# Altium schematic sheet [1] - POWER.SchDoc("[1] - POWER")
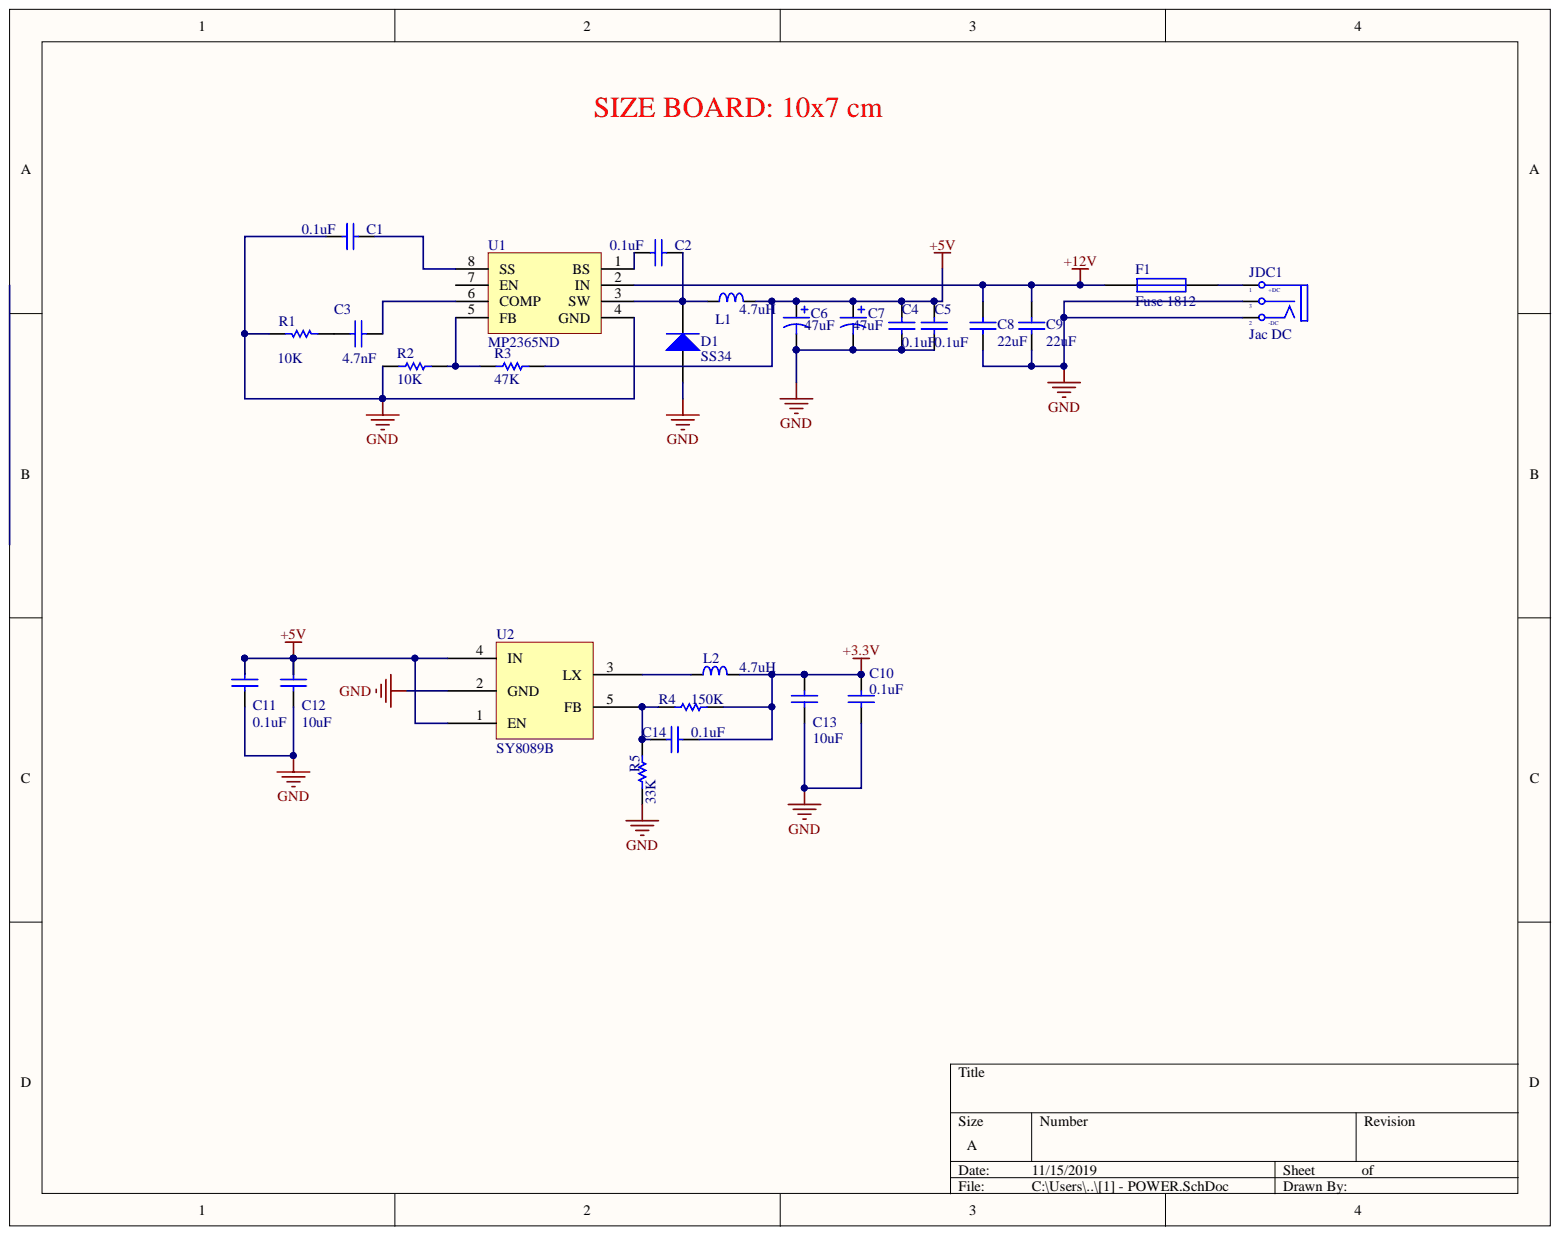
[diagram p0: [1] - POWER.SchDoc]

## Parts
C1  0.1uF  [CN-SMD-0603]
C2  0.1uF  [CN-SMD-0603]
C3  4.7nF  [CN-SMD-0603]
C4  0.1uF  [CN-SMD-0603]
C5  0.1uF  [CN-SMD-0603]
C6  47uF  [Cap size C]
C7  47uF  [Cap size C]
C8  22uF  [CN-SMD-0805]
C9  22uF  [CN-SMD-0805]
C10  0.1uF  [CN-SMD-0603]
C11  0.1uF  [CN-SMD-0603]
C12  10uF  [CN-SMD-0805]
C13  10uF  [CN-SMD-0805]
C14  0.1uF  [CN-SMD-0603]
D1  SS34  [DO-214AC]
F1  Fuse 1812  [fuse_1812]
JDC1  Jac DC  [DC0]
L1  4.7uH  [INDUCTOR CD75]
L2  4.7uH  [INDUCTOR CD75]
R1  10K  [RES 0603]
R2  10K  [RES 0603]
R3  47K  [RES 0603]
R4  150K  [RES 0603]
R5  33K  [RES 0603]
U1  MP2365ND  [MP2365ND]
U2  SY8089B  [SOT23-5]

## Nets
+3.3V: C10.1 C13.2 C14.1 L2.2 R4.1
+5V: C4.2 C5.2 C6.1 C7.1 C11.2 C12.2 L1.1 R3.2 U2.1(EN) U2.4(IN)
+12V: C8.1 C9.2 F1.1 U1.2(IN)
GND: C1.1 C4.1 C5.1 C6.2 C7.2 C8.2 C9.1 C10.2 C11.1 C12.1 C13.1 D1.1 JDC1.2 JDC1.3 R1.2 R2.1 R5.1 U1.4(GND) U2.2(GND)
NetC1_2: C1.2 U1.8(SS)
NetC2_1: C2.1 U1.1(BS)
NetC2_2: C2.2 D1.2 L1.2 U1.3(SW)
NetC3_1: C3.1 R1.1
NetC3_2: C3.2 U1.6(COMP)
NetC14_2: C14.2 R4.2 R5.2 U2.5(FB)
NetF1_2: F1.2 JDC1.1
NetL2_1: L2.1 U2.3(LX)
NetR2_2: R2.2 R3.1 U1.5(FB)
N00005:

Not connected: U1 7(EN)

## Library data of the parts
C1 C2 C4 C5 C10 C11 C14 = CN0603-0.1uF: CC0603KPX7R9BB104 0603 0.1 uF 50 V ±10% Tolerance X7R SMT Multilayer Ceramic Capacitor; Manufacturer 1: Yageo; Capacitance: 100nF; Voltage Rating: 50V; Case/Package: 0603; Case Code (Imperial): 0603; Case Code (Metric): 1608; Component Kind: Standard; ComponentLink1Description: https://datasheet.ciiva.com/26829/getdatasheetpartid-653026-26829414.pdf?src-supplier=Verical; ComponentLink1URL: https://datasheet.ciiva.com/26829/getdatasheetpartid-653026-26829414.pdf?src-supplier=Verical; ComponentLink2Description: http://datasheet.octopart.com/CC0603KPX7R9BB104-Yageo-datasheet-10883670.pdf; ComponentLink2URL: http://datasheet.octopart.com/CC0603KPX7R9BB104-Yageo-datasheet-10883670.pdf; ComponentLink3Description: http://datasheet.octopart.com/CC0603KPX7R9BB104-Yageo-datasheet-68298032.pdf?src-supplier=Future+Electronics; ComponentLink3URL: http://datasheet.octopart.com/CC0603KPX7R9BB104-Yageo-datasheet-68298032.pdf?src-supplier=Future+Electronics; ComponentLink4Description: http://datasheet.octopart.com/CC0603KPX7R9BB104-Yageo-datasheet-78855685.pdf?src-supplier=Future+Electronics; ComponentLink4URL: http://datasheet.octopart.com/CC0603KPX7R9BB104-Yageo-datasheet-78855685.pdf?src-supplier=Future+Electronics; ComponentLink5Description: http://datasheet.octopart.com/CC0603KPX7R9BB104-Yageo-datasheet-82288757.pdf?src-supplier=Future+Electronics; ComponentLink5URL: http://datasheet.octopart.com/CC0603KPX7R9BB104-Yageo-datasheet-82288757.pdf?src-supplier=Future+Electronics; ComponentLink6Description: http://datasheet.octopart.com/CC0603KPX7R9BB104-Yageo-datasheet-137700084.pdf?src-supplier=Future+Electronics; ComponentLink6URL: http://datasheet.octopart.com/CC0603KPX7R9BB104-Yageo-datasheet-137700084.pdf?src-supplier=Future+Electronics; Composition: Ceramic; Depth: 0.8mm; Dielectric: X7R; Halogen Free: Halogen Free; Height: 0.8mm; Lead Free: Lead Free; Length: 1.6mm; Manufacturer Part Number 1: CC0603KPX7R9BB104; Max Operating Temperature: 125°C; Min Operating Temperature: -55°C; Mount: Surface Mount; Number of Pins: 2; Packaging: Tape and Reel; Pricing 1: 1=0.10000, 10=0.06200, 100=0.02780, 500=0.01988, 1000=0.01562, 2500=0.01420, 5000=0.01306 (USD); Radiation Hardening: No; Stock 1: 190619; Supplier 1: Digi-Key; Termination: SMD/SMT; Thickness: 0.035inch; Tolerance: 10%; Voltage Rating (DC): 50V; Weight: 7.1E-05oz; Width: 0.031inch; Library Name: IOT_HIEP.SchLib
C3 = CN0603-4.7nF: CC0603KRX7R9BB472 Surface Mount Ceramic Multilayer Capacitor 0.0047uF 10% X7R 50V 0603 Paper T/R; Manufacturer 1: Yageo; Dissipation Factor: 2.5%; Insulation Resistance: 10GR; Capacitance: 4.7nF; Case/Package: 0603; Case Code (Imperial): 0603; Case Code (Metric): 1608; Component Kind: Standard; ComponentLink1Description: https://datasheet.ciiva.com/144/mlccorderingcode-144445.pdf?src-supplier=Digi-Key; ComponentLink1URL: https://datasheet.ciiva.com/144/mlccorderingcode-144445.pdf?src-supplier=Digi-Key; ComponentLink2Description: https://datasheet.ciiva.com/144/mlccorderingcode-144446.pdf?src-supplier=Digi-Key; ComponentLink2URL: https://datasheet.ciiva.com/144/mlccorderingcode-144446.pdf?src-supplier=Digi-Key; ComponentLink3Description: https://datasheet.ciiva.com/144/mlccorderingcode-144447.pdf?src-supplier=Digi-Key; ComponentLink3URL: https://datasheet.ciiva.com/144/mlccorderingcode-144447.pdf?src-supplier=Digi-Key; ComponentLink4Description: https://datasheet.ciiva.com/11464/upy-gphc-x7r-6-3v-to-50v-8-11464743.pdf?src-supplier=Avnet; ComponentLink4URL: https://datasheet.ciiva.com/11464/upy-gphc-x7r-6-3v-to-50v-8-11464743.pdf?src-supplier=Avnet; ComponentLink5Description: https://datasheet.ciiva.com/16625/upy-gphc-x7r-6-3v-to-50v-8-16625534.pdf?src-supplier=Avnet+Europe; ComponentLink5URL: https://datasheet.ciiva.com/16625/upy-gphc-x7r-6-3v-to-50v-8-16625534.pdf?src-supplier=Avnet+Europe; ComponentLink6Description: https://datasheet.ciiva.com/8033/1489800-8033903.pdf?src-supplier=Element14; ComponentLink6URL: https://datasheet.ciiva.com/8033/1489800-8033903.pdf?src-supplier=Element14; ComponentLink7Description: http://datasheet.octopart.com/CC0603KRX7R9BB472-Yageo-datasheet-10883670.pdf; ComponentLink7URL: http://datasheet.octopart.com/CC0603KRX7R9BB472-Yageo-datasheet-10883670.pdf; ComponentLink8Description: http://datasheet.octopart.com/CC0603KRX7R9BB472-Yageo-datasheet-62336348.pdf?src-supplier=Newark; ComponentLink8URL: http://datasheet.octopart.com/CC0603KRX7R9BB472-Yageo-datasheet-62336348.pdf?src-supplier=Newark; ComponentLink9Description: http://datasheet.octopart.com/CC0603KRX7R9BB472-Yageo-datasheet-5301394.pdf?src-supplier=Newark; ComponentLink9URL: http://datasheet.octopart.com/CC0603KRX7R9BB472-Yageo-datasheet-5301394.pdf?src-supplier=Newark; ComponentLink10Description: http://datasheet.octopart.com/CC0603KRX7R9BB472-Yageo-datasheet-15895757.pdf?src-supplier=Future+Electronics; ComponentLink10URL: http://datasheet.octopart.com/CC0603KRX7R9BB472-Yageo-datasheet-15895757.pdf?src-supplier=Future+Electronics; ComponentLink11Description: http://datasheet.octopart.com/CC0603KRX7R9BB472-Yageo-datasheet-27626582.pdf?src-supplier=Future+Electronics; ComponentLink11URL: http://datasheet.octopart.com/CC0603KRX7R9BB472-Yageo-datasheet-27626582.pdf?src-supplier=Future+Electronics; ComponentLink12Description: http://datasheet.octopart.com/CC0603KRX7R9BB472-Yageo-datasheet-30457685.pdf?src-supplier=Seeed+Studio; ComponentLink12URL: http://datasheet.octopart.com/CC0603KRX7R9BB472-Yageo-datasheet-30457685.pdf?src-supplier=Seeed+Studio; ComponentLink13Description: http://datasheet.octopart.com/CC0603KRX7R9BB472-Yageo-datasheet-17600895.pdf?src-supplier=TME; ComponentLink13URL: http://datasheet.octopart.com/CC0603KRX7R9BB472-Yageo-datasheet-17600895.pdf?src-supplier=TME; ComponentLink14Description: http://datasheet.octopart.com/CC0603KRX7R9BB472-Yageo-datasheet-137700084.pdf?src-supplier=Future+Electronics; ComponentLink14URL: http://datasheet.octopart.com/CC0603KRX7R9BB472-Yageo-datasheet-137700084.pdf?src-supplier=Future+Electronics; ComponentLink15Description: http://datasheet.octopart.com/CC0603KRX7R9BB472-Yageo-datasheet-118396.pdf?src-supplier=Digi-Key; ComponentLink15URL: http://datasheet.octopart.com/CC0603KRX7R9BB472-Yageo-datasheet-118396.pdf?src-supplier=Digi-Key; ComponentLink16Description: http://datasheet.octopart.com/CC0603KRX7R9BB472-Yageo-datasheet-8324192.pdf?src-supplier=Future+Electronics; ComponentLink16URL: http://datasheet.octopart.com/CC0603KRX7R9BB472-Yageo-datasheet-8324192.pdf?src-supplier=Future+Electronics; ComponentLink17Description: http://datasheet.octopart.com/CC0603KRX7R9BB472-Yageo-datasheet-499490.pdf?src-supplier=Element14; ComponentLink17URL: http://datasheet.octopart.com/CC0603KRX7R9BB472-Yageo-datasheet-499490.pdf?src-supplier=Element14; ComponentLink18Description: http://datasheet.octopart.com/CC0603KRX7R9BB472-Yageo-datasheet-8701427.pdf?src-supplier=Element14; ComponentLink18URL: http://datasheet.octopart.com/CC0603KRX7R9BB472-Yageo-datasheet-8701427.pdf?src-supplier=Element14; ComponentLink19Description: http://datasheet.octopart.com/CC0603KRX7R9BB472-Yageo-datasheet-12526321.pdf?src-supplier=Future+Electronics; ComponentLink19URL: http://datasheet.octopart.com/CC0603KRX7R9BB472-Yageo-datasheet-12526321.pdf?src-supplier=Future+Electronics; Composition: Ceramic; Depth: 0.8mm; Dielectric: X7R; Dielectric Material: Ceramic; Halogen Free: Halogen Free; Height: 0.8mm; Lead Free: Lead Free; Length: 1.6mm; Manufacturer Part Number 1: CC0603KRX7R9BB472; Material: Ceramic; Max Operating Temperature: 125°C; Min Operating Temperature: -55°C; Mount: Surface Mount; Number of Pins: 2; Package Quantity: 1; Packaging: Tape and Reel; Pricing 1: 1=0.10000, 10=0.05200, 100=0.02320, 500=0.01656, 1000=0.01301 (USD); Radiation Hardening: No; REACH SVHC: Unknown; Stock 1: 731800; Supplier 1: Digi-Key; Termination: SMD/SMT; Thickness: 0.035inch; Tolerance: 10%; Voltage: 50V; Voltage Rating: 50V; Voltage Rating (DC): 50V; Width: 0.8mm; Library Name: IOT_HIEP.SchLib
C6 C7 = CN6032-47uF: TPSC476M016R0350 CAP TANT 47UF 16V 20% 2312; Manufacturer 1: Kyocera AVX; Dielectric Material: Tantalum; Dissipation Factor: 6%; ESR (Equivalent Series Resistance): 350mR; Polarity: Polar; Capacitance: 47uF; Case/Package: Molded; Case Code (Imperial): 2312; Case Code (Metric): 6032; Component Kind: Standard; ComponentLink1Description: https://datasheet.ciiva.com/264/tps-264405.pdf?src-supplier=Digi-Key; ComponentLink1URL: https://datasheet.ciiva.com/264/tps-264405.pdf?src-supplier=Digi-Key; ComponentLink2Description: https://datasheet.ciiva.com/264/tps-264406.pdf?src-supplier=Digi-Key; ComponentLink2URL: https://datasheet.ciiva.com/264/tps-264406.pdf?src-supplier=Digi-Key; ComponentLink3Description: https://datasheet.ciiva.com/22667/1724878-22667574.pdf?src-supplier=Element14; ComponentLink3URL: https://datasheet.ciiva.com/22667/1724878-22667574.pdf?src-supplier=Element14; ComponentLink4Description: https://datasheet.ciiva.com/264/tps-264407.pdf?src-supplier=Digi-Key; ComponentLink4URL: https://datasheet.ciiva.com/264/tps-264407.pdf?src-supplier=Digi-Key; ComponentLink5Description: https://datasheet.ciiva.com/28514/1724878-28514871.pdf?src-supplier=Element14; ComponentLink5URL: https://datasheet.ciiva.com/28514/1724878-28514871.pdf?src-supplier=Element14; Depth: 3.2mm; Features: General Purpose; Height: 2.8mm; Height - Seated (Max): 0.11inch; Lead Free: Lead Free; Length: 6mm; Manufacturer Part Number 1: TPSC476M016R0350; Max Operating Temperature: 125°C; Min Operating Temperature: -55°C; Mount: Surface Mount; Number of Pins: 2; Package Quantity: 1; Packaging: Tape and Reel; Pricing 1: 1=1.31000, 10=1.03500, 100=0.77630 (USD); Radiation Hardening: No; REACH SVHC: No SVHC; RoHS: Yes; Stock 1: 3387; Supplier 1: Digi-Key; Termination: SMD/SMT; Tolerance: 20%; Voltage: 16V; Voltage Rating: 16V; Voltage Rating (DC): 16V; Width: 3.2mm; Library Name: IOT_HIEP.SchLib
C8 C9 = CN0805-22uF: CC0805MKX5R6BB226 0805 22 uF 10 V ±20 % Tolerance X5R SMT Multilayer Ceramic Capacitor; Manufacturer 1: Yageo; Tolerance: 20%; Voltage Rating: 10V; Capacitance: 22uF; Case/Package: 0805; Case Code (Imperial): 0805; Case Code (Metric): 2012; Component Kind: Standard; ComponentLink1Description: https://datasheet.ciiva.com/26863/getdatasheetpartid-573628-26863004.pdf?src-supplier=Verical; ComponentLink1URL: https://datasheet.ciiva.com/26863/getdatasheetpartid-573628-26863004.pdf?src-supplier=Verical; ComponentLink2Description: http://datasheet.octopart.com/CC0805MKX5R6BB226-Yageo-datasheet-24580494.pdf?src-supplier=Future+Electronics; ComponentLink2URL: http://datasheet.octopart.com/CC0805MKX5R6BB226-Yageo-datasheet-24580494.pdf?src-supplier=Future+Electronics; ComponentLink3Description: http://datasheet.octopart.com/CC0805MKX5R6BB226-Yageo-datasheet-27626583.pdf?src-supplier=Future+Electronics; ComponentLink3URL: http://datasheet.octopart.com/CC0805MKX5R6BB226-Yageo-datasheet-27626583.pdf?src-supplier=Future+Electronics; ComponentLink4Description: http://datasheet.octopart.com/CC0805MKX5R6BB226-Yageo-datasheet-86397281.pdf?src-supplier=Future+Electronics; ComponentLink4URL: http://datasheet.octopart.com/CC0805MKX5R6BB226-Yageo-datasheet-86397281.pdf?src-supplier=Future+Electronics; Composition: Ceramic; Depth: 1.25mm; Dielectric: X5R; Halogen Free: Halogen Free; Lead Free: Lead Free; Length: 2mm; Manufacturer Part Number 1: CC0805MKX5R6BB226; Max Operating Temperature: 85°C; Min Operating Temperature: -55°C; Mount: Surface Mount; Packaging: Tape and Reel; Pricing 1: 1=0.41000, 10=0.28800, 100=0.17290, 500=0.12966, 1000=0.10950 (USD); Radiation Hardening: No; Stock 1: 18000; Supplier 1: Digi-Key; Termination: SMD/SMT; Thickness: 0.057inch; Voltage Rating (DC): 10V; Weight: 0.000194oz; Width: 1.25mm; Library Name: IOT_HIEP.SchLib
C12 C13 = CN0805_10uF: CC0805KKX5R8BB106 0805 10 uF 25 V ±10 % Tolerance X5R SMT Multilayer Ceramic Capacitor; Manufacturer 1: Yageo; Tolerance: 10%; Voltage Rating: 25V; Capacitance: 10uF; Case/Package: 0805; Case Code (Imperial): 0805; Case Code (Metric): 2012; Component Kind: Standard; ComponentLink1Description: http://www.mouser.com/ds/2/447/UPY-GPHC_X5R_4V-to-50V_25-1131599.pdf?src-supplier=Mouser; ComponentLink1URL: http://www.mouser.com/ds/2/447/UPY-GPHC_X5R_4V-to-50V_25-1131599.pdf?src-supplier=Mouser; ComponentLink2Description: http://components.arrow.com/part/dataview/0323_04166572?src-supplier=Arrow+Europe; ComponentLink2URL: http://components.arrow.com/part/dataview/0323_04166572?src-supplier=Arrow+Europe; ComponentLink3Description: http://www.yageo.com/documents/recent/UPY-GPHC_X5R_4V-to-50V_25.pdf?src-supplier=Digi-Key; ComponentLink3URL: http://www.yageo.com/documents/recent/UPY-GPHC_X5R_4V-to-50V_25.pdf?src-supplier=Digi-Key; ComponentLink4Description: http://datasheet.octopart.com/CC0805KKX5R8BB106-Yageo-datasheet-14389981.pdf?src-supplier=Seeed+Studio; ComponentLink4URL: http://datasheet.octopart.com/CC0805KKX5R8BB106-Yageo-datasheet-14389981.pdf?src-supplier=Seeed+Studio; ComponentLink5Description: http://datasheet.octopart.com/CC0805KKX5R8BB106-Yageo-datasheet-78855687.pdf?src-supplier=Future+Electronics; ComponentLink5URL: http://datasheet.octopart.com/CC0805KKX5R8BB106-Yageo-datasheet-78855687.pdf?src-supplier=Future+Electronics; ComponentLink6Description: http://datasheet.octopart.com/CC0805KKX5R8BB106-Yageo-datasheet-86397281.pdf?src-supplier=Future+Electronics; ComponentLink6URL: http://datasheet.octopart.com/CC0805KKX5R8BB106-Yageo-datasheet-86397281.pdf?src-supplier=Future+Electronics; Composition: Ceramic; Depth: 1.25mm; Dielectric: X5R; Halogen Free: Halogen Free; Lead Free: Lead Free; Length: 0.079inch; Manufacturer Part Number 1: CC0805KKX5R8BB106; Max Operating Temperature: 85°C; Min Operating Temperature: -55°C; Mount: Surface Mount; Packaging: Tape and Reel; Pricing 1: 1=0.48000, 10=0.33500, 100=0.20100, 500=0.15076, 1000=0.12730 (USD); Stock 1: 905270; Supplier 1: Digi-Key; Termination: SMD/SMT; Thickness: 0.057inch; Voltage Rating (DC): 25V; Weight: 0.000194oz; Width: 0.049inch; Library Name: IOT_HIEP.SchLib
D1 = Diode_SS34: SS34 DIODE SCHOTTKY 40V 3A SMC; Manufacturer 1: ON Semiconductor / Fairchild; Element Configuration: Single; Forward Voltage: 500mV; Max Forward Surge Current (Ifsm): 100A; Max Power Dissipation: 2.27W; Max Reverse Leakage Current: 500uA; Number of Elements: 1; Polarity: Standard; Average Rectified Current: 3A; Case/Package: SMC; Component Kind: Standard; ComponentLink1Description: https://datasheet.ciiva.com/911/ss34-911088.pdf?src-supplier=Avnet; ComponentLink1URL: https://datasheet.ciiva.com/911/ss34-911088.pdf?src-supplier=Avnet; ComponentLink2Description: https://datasheet.ciiva.com/4715/ss34-197057-4715255.pdf?src-supplier=Mouser; ComponentLink2URL: https://datasheet.ciiva.com/4715/ss34-197057-4715255.pdf?src-supplier=Mouser; ComponentLink3Description: https://datasheet.ciiva.com/34301/1090087-34301975.pdf?src-supplier=Jameco; ComponentLink3URL: https://datasheet.ciiva.com/34301/1090087-34301975.pdf?src-supplier=Jameco; ComponentLink4Description: https://datasheet.ciiva.com/23832/1725604-23832651.pdf?src-supplier=Newark; ComponentLink4URL: https://datasheet.ciiva.com/23832/1725604-23832651.pdf?src-supplier=Newark; ComponentLink5Description: https://datasheet.ciiva.com/34302/1547649-34302462.pdf?src-supplier=Jameco; ComponentLink5URL: https://datasheet.ciiva.com/34302/1547649-34302462.pdf?src-supplier=Jameco; ComponentLink6Description: https://datasheet.ciiva.com/911/ss34-911086.pdf?src-supplier=Digi-Key; ComponentLink6URL: https://datasheet.ciiva.com/911/ss34-911086.pdf?src-supplier=Digi-Key; ComponentLink7Description: https://datasheet.ciiva.com/8300/ss39-8300583.pdf?src-supplier=Avnet; ComponentLink7URL: https://datasheet.ciiva.com/8300/ss39-8300583.pdf?src-supplier=Avnet; ComponentLink8Description: https://datasheet.ciiva.com/911/ss34-911085.pdf?src-supplier=Digi-Key; ComponentLink8URL: https://datasheet.ciiva.com/911/ss34-911085.pdf?src-supplier=Digi-Key; ComponentLink9Description: https://datasheet.ciiva.com/7965/1774678-7965245.pdf?src-supplier=Element14; ComponentLink9URL: https://datasheet.ciiva.com/7965/1774678-7965245.pdf?src-supplier=Element14; ComponentLink10Description: https://datasheet.ciiva.com/911/ss34-911087.pdf?src-supplier=Digi-Key; ComponentLink10URL: https://datasheet.ciiva.com/911/ss34-911087.pdf?src-supplier=Digi-Key; Contact Plating: Tin,Matte; Current: 3A; Current Rating: 3A; Forward Current: 3A; Height: 2.75mm; Lead Free: Lead Free; Length: 7.15mm; Manufacturer Part Number 1: SS34; Max Operating Temperature: 150°C; Max Repetitive Reverse Voltage (Vrrm): 40V; Max Reverse Voltage (DC): 40V; Max Surge Current: 100A; Min Operating Temperature: -55°C; Mount: Surface Mount; Number of Pins: 2; Number of Terminals: 2; Output Current: 3A; Package Quantity: 1; Packaging: Tape and Reel; Peak Non-Repetitive Surge Current: 100A; Peak Reverse Current: 500uA; Pricing 1: 1=0.47000, 10=0.40600, 100=0.30310, 500=0.23816, 1000=0.18403 (USD); Radiation Hardening: No; REACH SVHC: No SVHC; RoHS: Yes; Stock 1: 1630; Supplier 1: Digi-Key; Voltage: 40V; Voltage Rating (DC): 40V; Width: 6.25mm; Library Name: IOT_HIEP.SchLib
F1 = Fuse 1: Fuse; Manufacturer: Littelfuse; Manufacturer Part Number: MINISMDC150F/24-2; Hold Current: 1.5A; Voltage Rating (DC): 24V; Component Kind: Standard; ComponentLink1Description: http://www.farnell.com/datasheets/2282569.pdf?src-supplier=Farnell; ComponentLink1URL: http://www.farnell.com/datasheets/2282569.pdf?src-supplier=Farnell; ComponentLink2Description: http://www.farnell.com/datasheets/1468628.pdf?src-supplier=Farnell; ComponentLink2URL: http://www.farnell.com/datasheets/1468628.pdf?src-supplier=Farnell; ComponentLink3Description: http://www.littelfuse.com/~/media/electronics/datasheets/resettable_ptcs/littelfuse_ptc_minismd_catalog_datasheet.pdf.pdf?src-supplier=Digi-Key; ComponentLink3URL: http://www.littelfuse.com/~/media/electronics/datasheets/resettable_ptcs/littelfuse_ptc_minismd_catalog_datasheet.pdf.pdf?src-supplier=Digi-Key; ComponentLink4Description: http://www.farnell.com/datasheets/1468628.pdf?src-supplier=Element14; ComponentLink4URL: http://www.farnell.com/datasheets/1468628.pdf?src-supplier=Element14; Lead Free: Lead Free; Max Operating Temperature: 85°C; Min Operating Temperature: -40°C; Pricing 1: 1 = 0.66000, 5 = 0.64400, 10 = 0.62400, 50 = 0.52480, 100 = 0.43560, 250 = 0.39600, 500 = 0.33660 (USD); REACH SVHC: Unknown; RoHS: No; Simulation: FUSE; Stock 1: 56020; Supplier 1: Digi-Key; Supplier Part Number 1: MINISMDC150F24-2CT-ND; Library Name: NestHouse.SCHLIB
JDC1 = DCIN_1: Jac DC input; Component Kind: Standard; Value: Jac DC; Library Name: THUVIENTUTAO.lib
L1 L2 = L-4.7uH: CDRH5D28RHPNP-4R7NC Fixed Inductors 4.7uH 3.05A; Manufacturer 1: Sumida; Core Material: Ferrite; Current Rating: 2.8A; DC Resistance (DCR): 43.1mR; Max DC Current: 3.7A; Shielding: Shielded; Test Frequency: 100kHz; Tolerance: 25%; Case/Package: Nonstandard; Component Kind: Standard; ComponentLink1Description: http://www.mouser.com/ds/2/390/CDRH5D28RHP-523509.pdf?src-supplier=Mouser; ComponentLink1URL: http://www.mouser.com/ds/2/390/CDRH5D28RHP-523509.pdf?src-supplier=Mouser; ComponentLink2Description: http://products.sumida.com/products/pdf/CDRH5D28RHP.pdf?src-supplier=Digi-Key; ComponentLink2URL: http://products.sumida.com/products/pdf/CDRH5D28RHP.pdf?src-supplier=Digi-Key; ComponentLink3Description: http://www.sumida.com/products/pdf/CDRH5D28,5D28R,5D28RHP.pdf?src-supplier=Avnet; ComponentLink3URL: http://www.sumida.com/products/pdf/CDRH5D28,5D28R,5D28RHP.pdf?src-supplier=Avnet; Depth: 6.2mm; Height: 3mm; Height - Seated (Max): 0.118inch; Inductance: 4.7uH; Lead Free: Lead Free; Length: 0.236inch; Manufacturer Part Number 1: CDRH5D28RHPNP-4R7NC; Max Operating Temperature: 105°C; Min Operating Temperature: -40°C; Mount: Surface Mount; Packaging: Tape and Reel; Pricing 1: 1=1.53000, 10=1.42000, 100=1.02700, 500=0.78660, 1000=0.69920 (USD); Radiation Hardening: No; RoHS: Yes; Saturation Current: 3.7A; Stock 1: 50646; Supplier 1: Digi-Key; Supplier Part Number 1: 4.7uH; Termination: SMD/SMT; Width: 0.232inch; Library Name: IOT_HIEP.SchLib
R1 R2 = RC0603_10K: AC0603FR-0710KL Res Thick Film 0603 10K Ohm 1% 0.1W(1/10W) ±100ppm/C Pad SMD Automotive T/R; Manufacturer 1: Yageo; Max Power Dissipation: 100mW; Resistance: 10kR; Temperature Coefficient: 100ppm/°C; Voltage Rating: 50V; Case/Package: 0603; Case Code (Imperial): 0603; Case Code (Metric): 1608; Component Kind: Standard; ComponentLink1Description: https://datasheet.ciiva.com/26862/getdatasheetpartid-724997-26862461.pdf?src-supplier=Verical; ComponentLink1URL: https://datasheet.ciiva.com/26862/getdatasheetpartid-724997-26862461.pdf?src-supplier=Verical; ComponentLink2Description: http://datasheet.octopart.com/AC0603FR-0710KL-Yageo-datasheet-12512238.pdf; ComponentLink2URL: http://datasheet.octopart.com/AC0603FR-0710KL-Yageo-datasheet-12512238.pdf; ComponentLink3Description: http://datasheet.octopart.com/AC0603FR-0710KL-Yageo-datasheet-13029202.pdf?src-supplier=Future+Electronics; ComponentLink3URL: http://datasheet.octopart.com/AC0603FR-0710KL-Yageo-datasheet-13029202.pdf?src-supplier=Future+Electronics; ComponentLink4Description: http://datasheet.octopart.com/AC0603FR-0710KL-Yageo-datasheet-24580492.pdf?src-supplier=Future+Electronics; ComponentLink4URL: http://datasheet.octopart.com/AC0603FR-0710KL-Yageo-datasheet-24580492.pdf?src-supplier=Future+Electronics; ComponentLink5Description: http://datasheet.octopart.com/AC0603FR-0710KL-Yageo-datasheet-137699919.pdf?src-supplier=Future+Electronics; ComponentLink5URL: http://datasheet.octopart.com/AC0603FR-0710KL-Yageo-datasheet-137699919.pdf?src-supplier=Future+Electronics; Composition: Thick Film; Depth: 0.8mm; Features: Moisture Resistant; Height: 0.022inch; Lead Free: Lead Free; Length: 1.6mm; Manufacturer Part Number 1: AC0603FR-0710KL; Max Operating Temperature: 155°C; Military Standard: Not; Min Operating Temperature: -55°C; Mount: Surface Mount; Number of Terminations: 2; Package Quantity: 1; Packaging: Tape and Reel; Power Rating: 100mW; Stock 1: 0; Supplier 1: Newark; Supplier Part Number 1: 10K; Termination: SMD/SMT; Tolerance: 1%; Width: 0.8mm; Library Name: IOT_HIEP.SchLib
R3 = RC0603_47K: AC0603JR-0747KL Res Thick Film 0603 47K Ohm 5% 0.1W(1/10W) +/-100ppm/ßC Epoxy SMD Automotive T/R; Manufacturer 1: Yageo; Max Power Dissipation: 100mW; Resistance: 47kR; Temperature Coefficient: 100ppm/°C; Voltage Rating: 50V; Case/Package: 0603; Case Code (Imperial): 0603; Case Code (Metric): 1608; Component Kind: Standard; ComponentLink1Description: http://www.mouser.com/ds/2/447/yageodocoutput-1111992.pdf?src-supplier=Mouser; ComponentLink1URL: http://www.mouser.com/ds/2/447/yageodocoutput-1111992.pdf?src-supplier=Mouser; ComponentLink2Description: http://www.yageo.com/NewPortal/yageodocoutput?fileName=/pdf/R-Chip/PYu-AC_51_RoHS_L_6.pdf&src-supplier=Digi-Key; ComponentLink2URL: http://www.yageo.com/NewPortal/yageodocoutput?fileName=/pdf/R-Chip/PYu-AC_51_RoHS_L_6.pdf&src-supplier=Digi-Key; ComponentLink3Description: http://datasheet.octopart.com/AC0603JR-0747KL-Yageo-datasheet-12512238.pdf; ComponentLink3URL: http://datasheet.octopart.com/AC0603JR-0747KL-Yageo-datasheet-12512238.pdf; ComponentLink4Description: http://datasheet.octopart.com/AC0603JR-0747KL-Yageo-datasheet-83209224.pdf?src-supplier=Future+Electronics; ComponentLink4URL: http://datasheet.octopart.com/AC0603JR-0747KL-Yageo-datasheet-83209224.pdf?src-supplier=Future+Electronics; ComponentLink5Description: http://datasheet.octopart.com/AC0603JR-0747KL-Yageo-datasheet-137699919.pdf?src-supplier=Future+Electronics; ComponentLink5URL: http://datasheet.octopart.com/AC0603JR-0747KL-Yageo-datasheet-137699919.pdf?src-supplier=Future+Electronics; Composition: Thick Film; Features: Moisture Resistant; Height: 0.022inch; Length: 0.063inch; Manufacturer Part Number 1: AC0603JR-0747KL; Max Operating Temperature: 155°C; Min Operating Temperature: -55°C; Number of Terminations: 2; Packaging: Tape and Reel; Power Rating: 100mW; Pricing 1: 1=0.10000, 10=0.02300, 100=0.00940, 1000=0.00421, 2500=0.00366 (USD); Stock 1: 79182; Supplier 1: Digi-Key; Termination: SMD/SMT; Tolerance: 5%; Width: 0.031inch; Library Name: IOT_HIEP.SchLib
R4 = RC0603_150K: RC0603JR-07150KL Res Thick Film 1.6 x 0.8 mm 150K Ohm 5% 0.1W(1/10W) 100ppm/ C Molded SMD Paper T/R; Manufacturer 1: Yageo; Power Rating: 100mW; Resistance: 150kR; Temperature Coefficient: 100ppm/°C; Voltage Rating: 50V; Working Voltage: 75V; Case/Package: 0603; Case Code (Imperial): 0603; Case Code (Metric): 1608; Component Kind: Standard; ComponentLink1Description: https://datasheet.ciiva.com/7746/443971-7746439.pdf?src-supplier=Element14; ComponentLink1URL: https://datasheet.ciiva.com/7746/443971-7746439.pdf?src-supplier=Element14; ComponentLink2Description: https://datasheet.ciiva.com/6068/443971-6068184.pdf?src-supplier=Farnell; ComponentLink2URL: https://datasheet.ciiva.com/6068/443971-6068184.pdf?src-supplier=Farnell; ComponentLink3Description: https://datasheet.ciiva.com/7746/443971-7746440.pdf?src-supplier=Element14; ComponentLink3URL: https://datasheet.ciiva.com/7746/443971-7746440.pdf?src-supplier=Element14; ComponentLink4Description: https://datasheet.ciiva.com/12557/rc0603-272842-12557962.pdf?src-supplier=Mouser; ComponentLink4URL: https://datasheet.ciiva.com/12557/rc0603-272842-12557962.pdf?src-supplier=Mouser; ComponentLink5Description: https://datasheet.ciiva.com/6068/443971-6068092.pdf?src-supplier=Farnell; ComponentLink5URL: https://datasheet.ciiva.com/6068/443971-6068092.pdf?src-supplier=Farnell; ComponentLink6Description: https://datasheet.ciiva.com/46395/443971-46395086.pdf?src-supplier=Element14; ComponentLink6URL: https://datasheet.ciiva.com/46395/443971-46395086.pdf?src-supplier=Element14; ComponentLink7Description: http://datasheet.octopart.com/RC0603JR-07150KL-Yageo-datasheet-137700087.pdf?src-supplier=Future+Electronics; ComponentLink7URL: http://datasheet.octopart.com/RC0603JR-07150KL-Yageo-datasheet-137700087.pdf?src-supplier=Future+Electronics; ComponentLink8Description: http://datasheet.octopart.com/RC0603JR-07150KL-Yageo-datasheet-8328172.pdf?src-supplier=Newark; ComponentLink8URL: http://datasheet.octopart.com/RC0603JR-07150KL-Yageo-datasheet-8328172.pdf?src-supplier=Newark; ComponentLink9Description: http://datasheet.octopart.com/RC0603JR-07150KL-Yageo-datasheet-62067350.pdf?src-supplier=Element14; ComponentLink9URL: http://datasheet.octopart.com/RC0603JR-07150KL-Yageo-datasheet-62067350.pdf?src-supplier=Element14; ComponentLink10Description: http://datasheet.octopart.com/RC0603JR-07150KL-Yageo-datasheet-34211833.pdf?src-supplier=Element14; ComponentLink10URL: http://datasheet.octopart.com/RC0603JR-07150KL-Yageo-datasheet-34211833.pdf?src-supplier=Element14; ComponentLink11Description: http://datasheet.octopart.com/RC0603JR-07150KL-Yageo-datasheet-12596169.pdf?src-supplier=Future+Electronics; ComponentLink11URL: http://datasheet.octopart.com/RC0603JR-07150KL-Yageo-datasheet-12596169.pdf?src-supplier=Future+Electronics; ComponentLink12Description: http://datasheet.octopart.com/RC0603JR-07150KL-Yageo-datasheet-27584510.pdf?src-supplier=Future+Electronics; ComponentLink12URL: http://datasheet.octopart.com/RC0603JR-07150KL-Yageo-datasheet-27584510.pdf?src-supplier=Future+Electronics; ComponentLink13Description: http://datasheet.octopart.com/RC0603JR-07150KL-Yageo-datasheet-30459151.pdf?src-supplier=Seeed+Studio; ComponentLink13URL: http://datasheet.octopart.com/RC0603JR-07150KL-Yageo-datasheet-30459151.pdf?src-supplier=Seeed+Studio; ComponentLink14Description: http://datasheet.octopart.com/RC0603JR-07150KL-Yageo-datasheet-73766026.pdf; ComponentLink14URL: http://datasheet.octopart.com/RC0603JR-07150KL-Yageo-datasheet-73766026.pdf; ComponentLink15Description: http://datasheet.octopart.com/RC0603JR-07150KL-Yageo-datasheet-118562.pdf?src-supplier=Digi-Key; ComponentLink15URL: http://datasheet.octopart.com/RC0603JR-07150KL-Yageo-datasheet-118562.pdf?src-supplier=Digi-Key; ComponentLink16Description: http://datasheet.octopart.com/RC0603JR-07150KL-Yageo-datasheet-8324193.pdf?src-supplier=Future+Electronics; ComponentLink16URL: http://datasheet.octopart.com/RC0603JR-07150KL-Yageo-datasheet-8324193.pdf?src-supplier=Future+Electronics; ComponentLink17Description: http://datasheet.octopart.com/RC0603JR-07150KL-Yageo-datasheet-15599030.pdf?src-supplier=Future+Electronics; ComponentLink17URL: http://datasheet.octopart.com/RC0603JR-07150KL-Yageo-datasheet-15599030.pdf?src-supplier=Future+Electronics; Composition: Thick Film; Depth: 0.8mm; Height: 0.45mm; Lead Free: Lead Free; Length: 1.6mm; Manufacturer Part Number 1: RC0603JR-07150KL; Max Operating Temperature: 155°C; Military Standard: Not; Min Operating Temperature: -55°C; Number of Pins: 2; Number of Terminations: 2; Package Quantity: 5000; Packaging: Tape and Reel; Pricing 1: 1=0.10000, 10=0.01900, 100=0.00770, 1000=0.00347, 2500=0.00301 (USD); REACH SVHC: No SVHC; Stock 1: 721120; Supplier 1: Digi-Key; Termination: SMD/SMT; Tolerance: 5%; Voltage Rating (DC): 50V; Width: 0.8mm; Library Name: IOT_HIEP.SchLib
R5 = RC0603_33K: AC0603JR-0733KL Res Thick Film 0603 33K Ohm 5% 0.1W(1/10W) ±100ppm/C Pad SMD Automotive T/R; Manufacturer 1: Yageo; Max Power Dissipation: 100mW; Resistance: 33kR; Temperature Coefficient: 100ppm/°C; Voltage Rating: 50V; Case/Package: 0603; Case Code (Imperial): 0603; Case Code (Metric): 1608; Component Kind: Standard; ComponentLink1Description: http://www.mouser.com/ds/2/447/yageodocoutput-1111992.pdf?src-supplier=Mouser; ComponentLink1URL: http://www.mouser.com/ds/2/447/yageodocoutput-1111992.pdf?src-supplier=Mouser; ComponentLink2Description: http://www.yageo.com/NewPortal/yageodocoutput?fileName=/pdf/R-Chip/PYu-AC_51_RoHS_L_6.pdf&src-supplier=Digi-Key; ComponentLink2URL: http://www.yageo.com/NewPortal/yageodocoutput?fileName=/pdf/R-Chip/PYu-AC_51_RoHS_L_6.pdf&src-supplier=Digi-Key; ComponentLink3Description: http://datasheet.octopart.com/AC0603JR-0733KL-Yageo-datasheet-12512238.pdf; ComponentLink3URL: http://datasheet.octopart.com/AC0603JR-0733KL-Yageo-datasheet-12512238.pdf; ComponentLink4Description: http://datasheet.octopart.com/AC0603JR-0733KL-Yageo-datasheet-83209224.pdf?src-supplier=Future+Electronics; ComponentLink4URL: http://datasheet.octopart.com/AC0603JR-0733KL-Yageo-datasheet-83209224.pdf?src-supplier=Future+Electronics; ComponentLink5Description: http://datasheet.octopart.com/AC0603JR-0733KL-Yageo-datasheet-137699919.pdf?src-supplier=Future+Electronics; ComponentLink5URL: http://datasheet.octopart.com/AC0603JR-0733KL-Yageo-datasheet-137699919.pdf?src-supplier=Future+Electronics; Composition: Thick Film; Features: Moisture Resistant; Height: 0.022inch; Length: 0.063inch; Manufacturer Part Number 1: AC0603JR-0733KL; Max Operating Temperature: 155°C; Min Operating Temperature: -55°C; Number of Terminations: 2; Packaging: Tape and Reel; Power Rating: 100mW; Pricing 1: 1=0.10000, 10=0.02300, 100=0.00940, 1000=0.00421, 2500=0.00366 (USD); Stock 1: 20130; Supplier 1: Digi-Key; Termination: SMD/SMT; Tolerance: 5%; Width: 0.8mm; Library Name: IOT_HIEP.SchLib
U1 = Switching MP2365ND: Manufacturer: Monolithic Power Systems; Manufacturer Part Number: MP2365DN-LF-Z; Max Input Voltage: 28V; Max Output Voltage: 21V; Min Input Voltage: 4.75V; Min Output Voltage: 920mV; Nominal Supply Current: 1.3mA; Number of Outputs: 1; Output Configuration: Positive; Output Current: 3A; Output Type: Adjustable; Switching Frequency: 1.4MHz; Synchronous Rectifier: No; Case/Package: SOIC; Component Kind: Standard; ComponentLink1Description: http://www.mouser.com/ds/2/277/MP2365_r1.01-480735.pdf?src-supplier=Mouser; ComponentLink1URL: http://www.mouser.com/ds/2/277/MP2365_r1.01-480735.pdf?src-supplier=Mouser; ComponentLink2Description: http://www.monolithicpower.com/Page/DownLoad.aspx?ListID=149b7d2b-0bb2-4ba6-a219-a0495acb8b0b&&ItemID=36&src-supplier=Avnet; ComponentLink2URL: http://www.monolithicpower.com/Page/DownLoad.aspx?ListID=149b7d2b-0bb2-4ba6-a219-a0495acb8b0b&&ItemID=36&src-supplier=Avnet; ComponentLink3Description: http://www.monolithicpower.com/DesktopModules/DocumentManage/API/Document/getDocument?id=287&src-supplier=Digi-Key; ComponentLink3URL: http://www.monolithicpower.com/DesktopModules/DocumentManage/API/Document/getDocument?id=287&src-supplier=Digi-Key; ComponentLink4Description: http://www.monolithicpower.com/DesktopModules/DocumentManage/API/Document/GetDocument?id=287&src-supplier=Avnet+Asia; ComponentLink4URL: http://www.monolithicpower.com/DesktopModules/DocumentManage/API/Document/GetDocument?id=287&src-supplier=Avnet+Asia; ComponentLink5Description: http://datasheet.octopart.com/MP2365DN-LF-Z-Monolithic-Power-Systems-datasheet-13697211.pdf?src-supplier=Future+Electronics; ComponentLink5URL: http://datasheet.octopart.com/MP2365DN-LF-Z-Monolithic-Power-Systems-datasheet-13697211.pdf?src-supplier=Future+Electronics; ComponentLink6Description: http://datasheet.octopart.com/MP2365DN-LF-Z-Monolithic-Power-Systems-datasheet-28362030.pdf?src-supplier=Future+Electronics; ComponentLink6URL: http://datasheet.octopart.com/MP2365DN-LF-Z-Monolithic-Power-Systems-datasheet-28362030.pdf?src-supplier=Future+Electronics; Contact Plating: Tin; Description: MP2365ND; Lead Free: Lead Free; Max Operating Temperature: 85°C; Min Operating Temperature: -40°C; Mount: Surface Mount; Output Voltage: 21V; Package Quantity: 2500; Packaging: Tape and Reel; Pricing 1: 1 = 4.47000, 10 = 3.99100, 25 = 3.59200, 100 = 3.27280, 250 = 2.95352, 500 = 2.65020, 1000 = 2.23510 (USD); Radiation Hardening: No; Stock 1: 2848; Supplier 1: Digi-Key; Supplier Part Number 1: 1589-1115-1-ND; Library Name: NestHouse.SCHLIB
U2 = SY8089B: High Efficiency 5.5V, 2A continuous, 3A peak, 1MHz  Synchronous Step Down Regulator; Manufacturer: Silergy; Manufacturer Part Number: SY8089A; Suppiler 1: China; Case/Package: SOT23-5; Component Kind: Standard; Suppiler Part Number 1: SY8089A; Library Name: NestHouse.SCHLIB
